# Altium schematic sheet Power.SchDoc(Power)
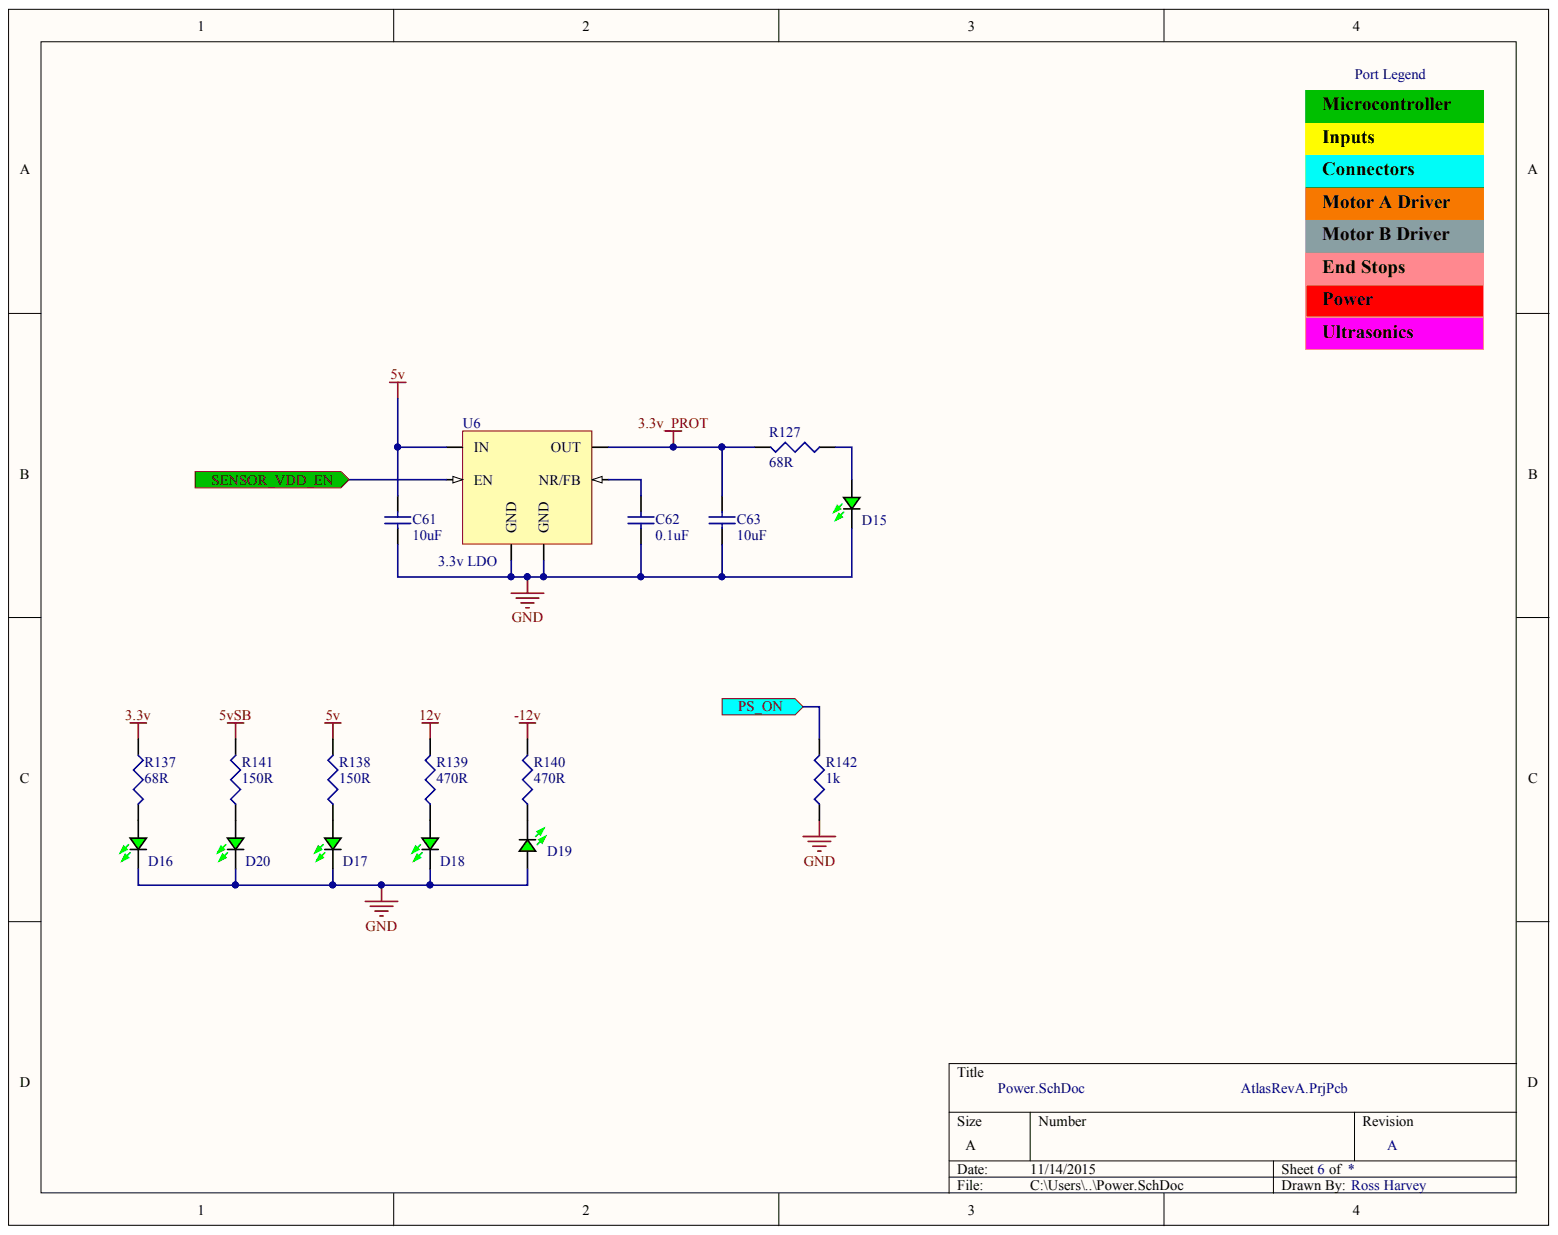
[diagram p0: Power.SchDoc]

## Parts
C61  10uF  [CAP1206R]
C62  0.1uF  [CAP0603W]
C63  10uF  [CAP1206R]
D15  LTST-S270KGKT  [LED Green 0603]  (value not drawn)
D16  LTST-S270KGKT  [LED Green 0603]  (value not drawn)
D17  LTST-S270KGKT  [LED Green 0603]  (value not drawn)
D18  LTST-S270KGKT  [LED Green 0603]  (value not drawn)
D19  LTST-S270KGKT  [LED Green 0603]  (value not drawn)
D20  LTST-S270KGKT  [LED Green 0603]  (value not drawn)
R127  68R  [RES0603W]
R137  68R  [RES0603W]
R138  150R  [RES0603W]
R139  470R  [RES0603W]
R140  470R  [RES0603W]
R141  150R  [RES0603W]
R142  1k  [RES0603W]
U6  3.3v LDO  [SOT-223-6]

## Ports
PS_ON, SENSOR_VDD_EN

## Nets
3.3V: R137.1
3.3V_PROT: C63.2 R127.2 U6.2(OUT)
5V: C61.2 R138.2 U6.1(IN)
5VSB: R141.2
12V: R139.2
-12V: R140.2
GND: C61.1 C62.2 C63.1 D15.1 D16.1 D17.1 D18.1 D19.2 D20.1 R142.1 U6.3(GND) U6.6(GND)
PS_ON: R142.2 ; port PS_ON
SENSOR_VDD_EN: U6.5(EN) ; port SENSOR_VDD_EN
NetC62_1: C62.1 U6.4(NR/FB)
NetD15_2: D15.2 R127.1
NetD16_2: D16.2 R137.2
NetD17_2: D17.2 R138.1
NetD18_2: D18.2 R139.1
NetD19_1: D19.1 R140.1
NetD20_2: D20.2 R141.1

## Library data of the parts
C61 C63 = 10uF: MFG1: Vishay; MFG1_PN: VJ1206V106ZXQTW1BC; Component Kind: Standard; Library Name: Altium Library.IntLib; Value: 10uF
C62 = 0.1uF: MFG1: Vishay; MFG1_PN: VJ0603Y104JXJPW1BC; Component Kind: Standard; Library Name: Altium Library.IntLib; Value: 0.1uF
D15-D20 = LED Green 0603: COLOR: Green; CURRENT: 20mA; MFG: Lite On; VFORWARD: 2v; Component Kind: Standard; Library Name: Altium Library.IntLib; MFG_PN: LTST-S270KGKT
R127 R137 = 68R (0603): Load: NL; MFG: Vishay; MFG_PN: CRCW060368R0FKEB; POWER: 0.1W; Tolerance: 1%; Component Kind: Standard; Library Name: Altium Library.IntLib; Value: 68R
R138 R141 = 150R (0603): Load: NL; MFG: Vishay; MFG_PN: CRCW0603150RFKEA; POWER: 0.1W; Tolerance: 1%; Component Kind: Standard; Library Name: Altium Library.IntLib; Value: 150R
R139 R140 = 470R (0603): Load: NL; MFG: Vishay; MFG_PN: CRCW0603470RFKEA; POWER: 0.1W; Tolerance: 1%; Component Kind: Standard; Library Name: Altium Library.IntLib; Value: 470R
R142 = 1k (0603): Load: NL; MFG: Vishay; MFG_PN: CRCW06031K00FKEA; POWER: 0.1W; Tolerance: 1%; Component Kind: Standard; Library Name: Altium Library.IntLib; Value: 1k
U6 = TPS73633DCQR: MFG: TI; Component Kind: Standard; Description: 3.3v LDO; FUNCTION: 3.3v LDO; Library Name: Altium Library.IntLib; MFG_PN: TPS73633DCQR
